# Altium schematic sheet dcdc300v.SchDoc(dcdc300v)
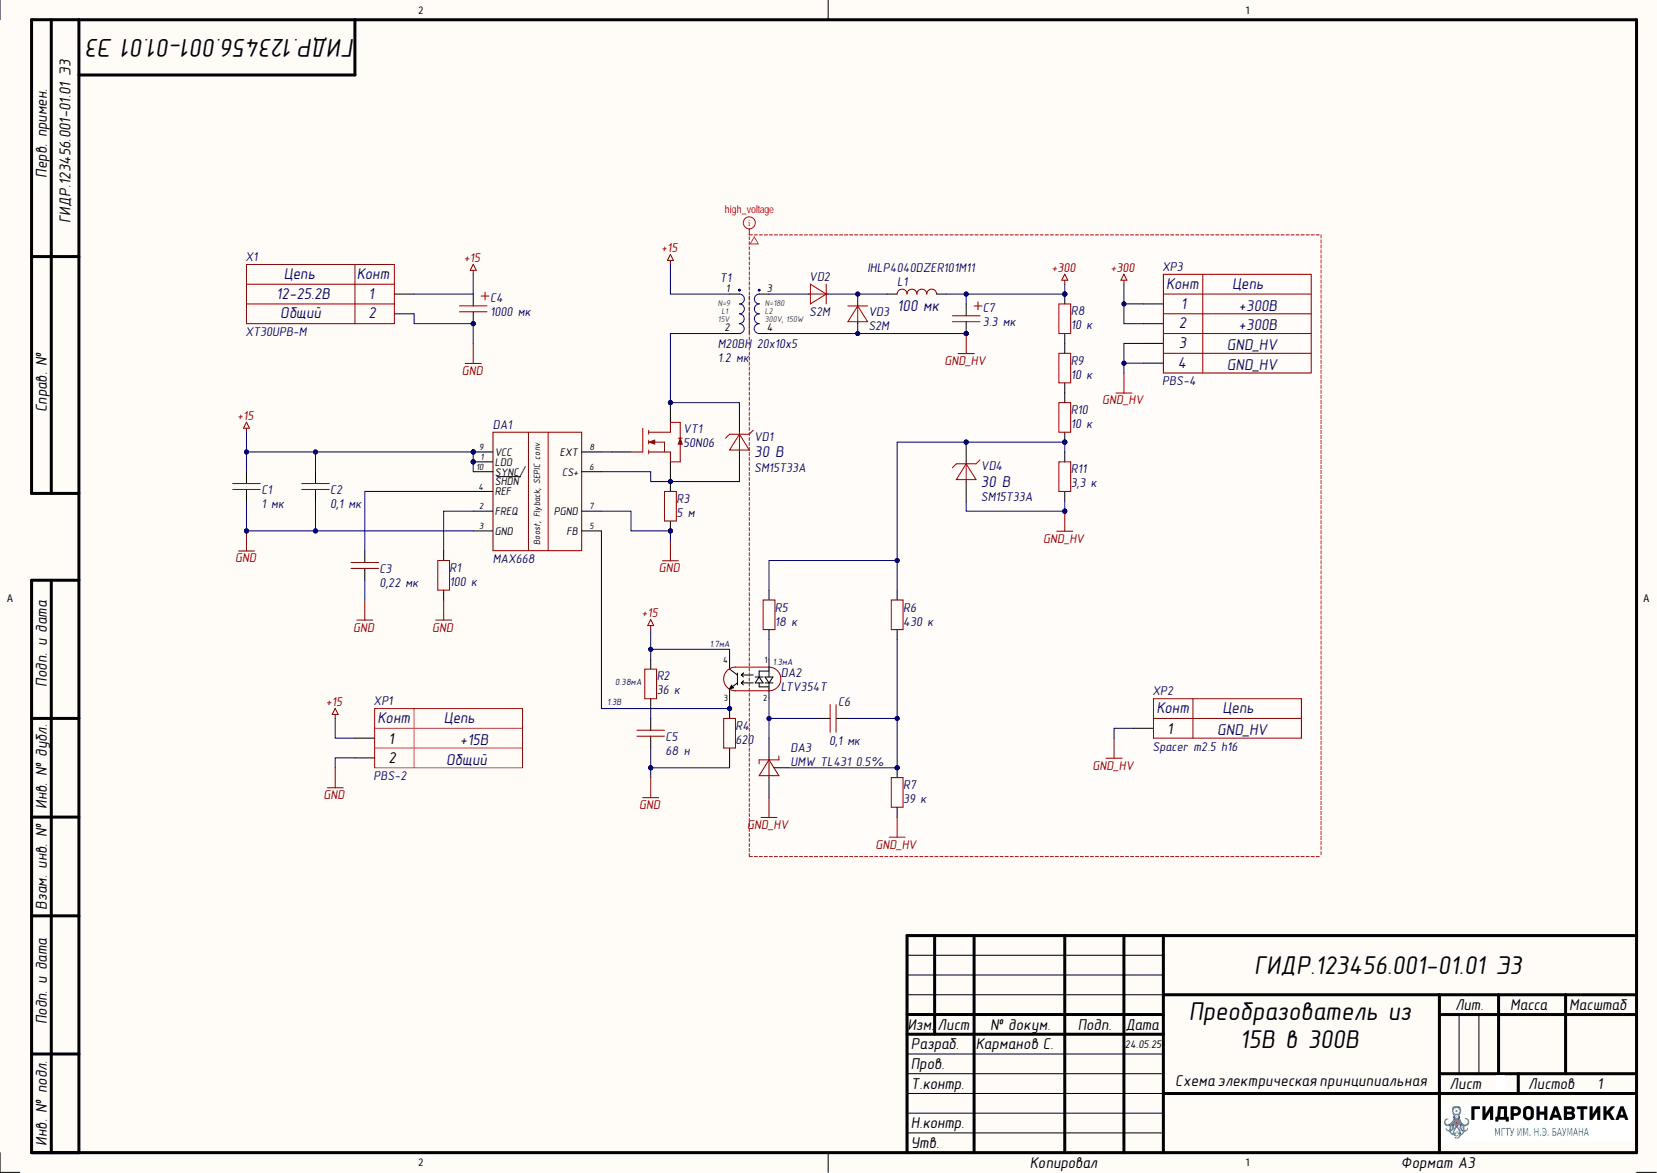
[diagram p0: dcdc300v.SchDoc]

## Parts
C1  value 1 мк  [0603C]  (hidden comment: Capacitor)
C2  value 0,1 мк  Tolerance: 0,1  [0603C]  (hidden comment: Capacitor)
C3  value 0,22 мк  [0603C]  (hidden comment: Capacitor)
C4  value 1000 мк  Capacity (uF): 1000  [CAP_EEVFK1V102M]  (hidden comment: Capacitor)
C5  value 68 н  [0603C]  (hidden comment: Capacitor)
C6  value 0,1 мк  Tolerance: 0,1  [0603C]  (hidden comment: Capacitor)
C7  value 3.3 мк  [8x12_radial]  (hidden comment: Capacitor)
DA1  MAX668  [uSOP10]
DA2  LTV354T  [SOP4-2_54]
DA3  UMW TL431 0.5%  [SOT23-3]
L1  IHLP4040DZER101M11  value 100 мк  [IHLP-4040]
R1  value 100 к  [0603R]  (hidden comment: Resistor)
R2  value 36 к  [0603R]  (hidden comment: Resistor)
R3  value 5 м  [2512R]  (hidden comment: Resistor)
R4  value 620  [0603R]  (hidden comment: Resistor)
R5  value 18 к  [0603R]  (hidden comment: Resistor)
R6  value 430 к  [0603R]  (hidden comment: Resistor)
R7  value 39 к  [0603R]  (hidden comment: Resistor)
R8  value 10 к  [1218R]  (hidden comment: Resistor)
R9  value 10 к  [1218R]  (hidden comment: Resistor)
R10  value 10 к  [1218R]  (hidden comment: Resistor)
R11  value 3,3 к  [1210R]  (hidden comment: Resistor)
T1  М20ВН 20х10х5  value 1.2 мк  [M20VN]
VD1  SM15T33A  value 30 В  [SMC]
VD2  S2M  [SMB]
VD3  S2M  [SMB]
VD4  SM15T33A  value 30 В  [SMC]
VT1  50N06  [DPAK]
X1  XT30UPB-M  Value1: 12-25.2В  Value2: Общий  [XT30UPB-M]
XP1  PBS-2  Value1: +15В  Value2: Общий  [PBS2_8.5]
XP2  Spacer m2.5 h16  Value1: GND_HV  [spacer_m2.5_h16]
XP3  PBS-4  Value1: +300В  Value3: GND_HV  [PBS4_8.5]

## Nets
+15: C1.2 C2.2 C4.1 DA1.1(LDO) DA1.9(VCC) DA1.10(SYNC/) DA2.4 R2.2 T1.1 X1.P XP1.1
+300: C7.1 L1.2 R8.2 XP3.1 XP3.2
GND: C1.1 C2.1 C3.1 C4.2 C5.1 DA1.3(GND) DA1.7(PGND) R1.1 R3.1 R4.1 X1.N XP1.2
GND_HV: C7.2 DA3.3 R7.1 R11.1 T1.4 VD3.1 VD4.1 XP2.1 XP3.3 XP3.4
NetC3_2: C3.2 DA1.4(REF)
NetC5_2: C5.2 DA1.5(FB) DA2.3 R2.1 R4.2
NetC6_1: C6.1 DA2.2 DA3.2
NetC6_2: C6.2 DA3.1 R6.2 R7.2
NetDA1_2: DA1.2(FREQ) R1.2
NetDA1_6: DA1.6(CS+) R3.2 VD1.1 VT1.3
NetDA1_8: DA1.8(EXT) VT1.1
NetDA2_1: DA2.1 R5.1
NetL1_1: L1.1 VD2.2 VD3.2
NetR5_2: R5.2 R6.1 R10.1 R11.2 VD4.2
NetR8_1: R8.1 R9.2
NetR9_1: R9.1 R10.2
NetT1_2: T1.2 VD1.2 VT1.2
NetT1_3: T1.3 VD2.1

## Library data of the parts
C1 = Capacitor_1u_10_50V_X7R_0603C: Конденсатор; Capacity (nF): 1000; Database Table Name: Capacitors_ceramic$; Dielectric: X7R; Library Path2: Schematic\Capacitor.SchLib; Tolerance: 0,1; Voltage (V): 50; Component Kind: Standard; Library Name: Hydrolib.DbLib; Part Number: Capacitor_1u_10_50V_X7R_0603C
C2 C6 = Capacitor_0,1u_10_50V_X7R_0603C: Конденсатор; Capacity (nF): 100; Database Table Name: Capacitors_ceramic$; Dielectric: X7R; Library Path2: Schematic\Capacitor.SchLib; Voltage (V): 50; Component Kind: Standard; Library Name: Hydrolib.DbLib; Part Number: Capacitor_0,1u_10_50V_X7R_0603C
C3 = Capacitor_0,22u_10_50V_X7R_0603C: Конденсатор; Capacity (nF): 220; Database Table Name: Capacitors_ceramic$; Dielectric: X7R; Library Path2: Schematic\Capacitor.SchLib; Tolerance: 0,1; Voltage (V): 50; Component Kind: Standard; Library Name: Hydrolib.DbLib; Part Number: Capacitor_0,22u_10_50V_X7R_0603C
C4 = EEVFK1V102M: Конденсатор алюминиевый электролитический 1000мкФ 25В 20% Low ESR 1100мА SMD12.5x13.5мм; Database Table Name: Capacitors_electrolytic$; Tolerance: 20; Type: SMD Aluminum; Voltage (V): 35; Component Kind: Standard; Library Name: Hydrolib.DbLib; Part Number: EEVFK1V102M
C5 = Capacitor_68n_10_50V_X7R_0603C: Конденсатор; Capacity (nF): 68; Database Table Name: Capacitors_ceramic$; Dielectric: X7R; Library Path2: Schematic\Capacitor.SchLib; Tolerance: 0,1; Voltage (V): 50; Component Kind: Standard; Library Name: Hydrolib.DbLib; Part Number: Capacitor_68n_10_50V_X7R_0603C
C7 = JRB2G3R3M03500800120000B: К50-35, 3.3мкФ, 400В, 105°C, 20%, 8x12мм P:3.5мм; Capacity (uF): 3,3; Database Table Name: Capacitors_electrolytic$; Tolerance: 20; Type: Aluminum; Voltage (V): 400; Component Kind: Standard; Library Name: Hydrolib.DbLib; Part Number: JRB2G3R3M03500800120000B
DA1 = MAX668: Boost, Flyback, SEPIC преобразователь. 10-uMAX/uSOP; Database Table Name: Chips$; MF: Maxim Integrated; MP: MAX668EUB+T; Availability: In Stock; Check_prices: https://www.snapeda.com/parts/MAX668EUB+T/Maxim+Integrated/view-part/?ref=eda; Component Kind: Standard; Library Name: Hydrolib.DbLib; Package: MICROMAX-10 Maxim; Part Number: MAX668; Price: None; Purchase-URL: https://pricing.snapeda.com/search/part/MAX668EUB+T/?ref=eda; SnapEDA_Link: https://www.snapeda.com/parts/MAX668EUB+T/Maxim+Integrated/view-part/?ref=snap
DA2 = LTV354T: Оптопара с транзисторным выходом, UMW; Database Table Name: Chips$; Check_prices: https://www.snapeda.com/parts/LTV816/Lite-On/view-part/?ref=eda; Component Kind: Standard; Library Name: Hydrolib.DbLib; Part Number: LTV354T; SnapEDA_Link: https://www.snapeda.com/parts/LTV816/Lite-On/view-part/?ref=snap
DA3 = UMW TL431 0.5%: Источник опорного напряжения , программируемый стабилитрон [SOT-23-3]; Database Table Name: Other$; Component Kind: Standard; Library Name: Hydrolib.DbLib; Part Number: UMW TL431 0.5%
L1 = IHLP4040DZER101M11: ЧИП-индуктивность экранированная 47мкГн ±20% 3,3A 145мОм 4040; Current (A): 3,3; Database Table Name: Inductance$; Inductance (μH): 100; Component Kind: Standard; Library Name: Hydrolib.DbLib; Part Number: IHLP4040DZER101M11
R1 = Resistor_100k_1_0603: Резистор номиналом 100 кОм и точностью 1%; Database Table Name: Resistors$; Component Kind: Standard; Library Name: Hydrolib.DbLib; Part Number: Resistor_100k_1_0603; Tolerance: 1%
R2 = Resistor_36k_1_0603: Резистор номиналом 36 кОм и точностью 1%; Database Table Name: Resistors$; Component Kind: Standard; Library Name: Hydrolib.DbLib; Part Number: Resistor_36k_1_0603; Tolerance: 1%
R3 = CRE2512-FZ-R005E-3: Резистор номиналом 5 мОм, точностью 1%, 3Вт; Database Table Name: Resistors$; Component Kind: Standard; Library Name: Hydrolib.DbLib; Part Number: CRE2512-FZ-R005E-3; Tolerance: 1%
R4 = Resistor_620_1_0603: Резистор номиналом 620 Ом и точностью 1%; Database Table Name: Resistors$; Component Kind: Standard; Library Name: Hydrolib.DbLib; Part Number: Resistor_620_1_0603; Tolerance: 1%
R5 = Resistor_18k_1_0603: Резистор номиналом 18 кОм и точностью 1%; Database Table Name: Resistors$; Component Kind: Standard; Library Name: Hydrolib.DbLib; Part Number: Resistor_18k_1_0603; Tolerance: 1%
R6 = Resistor_430k_1_0603: Резистор номиналом 430 кОм и точностью 1%; Database Table Name: Resistors$; Component Kind: Standard; Library Name: Hydrolib.DbLib; Part Number: Resistor_430k_1_0603; Tolerance: 1%
R7 = Resistor_39k_1_0603: Резистор номиналом 39 кОм и точностью 1%; Database Table Name: Resistors$; Component Kind: Standard; Library Name: Hydrolib.DbLib; Part Number: Resistor_39k_1_0603; Tolerance: 1%
R8-R10 = Resistor_10k_1_0603: Резистор номиналом 10 кОм и точностью 1%; Database Table Name: Resistors$; Component Kind: Standard; Library Name: Hydrolib.DbLib; Part Number: Resistor_10k_1_0603; Tolerance: 1%
R11 = Resistor_3.3k_1_0603: Резистор номиналом 3,3 кОм и точностью 1%; Database Table Name: Resistors$; Component Kind: Standard; Library Name: Hydrolib.DbLib; Part Number: Resistor_3.3k_1_0603; Tolerance: 1%
T1 = M20VN: Накопительная индуктивность для обратноходового преобразователя, L1=1.2uH, магнитопровод М20ВН, 20х10х5, первичная обмотка d1.3мм; Current (A): 15; Database Table Name: Inductance$; Inductance (μH): 1,2; Component Kind: Standard; Library Name: Hydrolib.DbLib; Part Number: M20VN
VD1 VD4 = SM15T33A: Защитный диод на 30 В, рассеиваемая мощность 1500 Вт; Database Table Name: TVS$; Power (W): 1500; Voltage nominal (V): 30; Component Kind: Standard; Library Name: Hydrolib.DbLib; Part Number: SM15T33A
VD2 VD3 = S2M: Выпрямительный диод на 1000 В, ном. ток 2 А; Current (A): 2; Database Table Name: Rectifier Diodes$; Repetitive peak reverse voltage (Vrrm): 1000; Component Kind: Standard; Library Name: Hydrolib.DbLib; Part Number: S2M
VT1 = 50N06: N-канальный MOSFET; Database Table Name: Transistors$; Напряжение С-И/К-Э: 60 В; Ток макс#: 50 А; Component Kind: Standard; Library Name: Hydrolib.DbLib; Part Number: 50N06
X1 = XT30UPB-M: 2-пиновый разъем с ключом; Database Table Name: Connectors$; System: Connector; Component Kind: Standard; Library Name: Hydrolib.DbLib; Part Number: XT30UPB-M
XP1 = PBS-2: Розетка 4 пина высота 8.5; Database Table Name: Connectors$; System: Connector; Component Kind: Standard; Datasheet: https://static.chipdip.ru/lib/986/DOC012986355.pdf; Library Name: Hydrolib.DbLib; Part Number: PBS-2
XP2 = spacer_m2.5_h16: Стойка М2.5, высота 16мм; Database Table Name: Other$; Component Kind: Standard; Library Name: Hydrolib.DbLib; Part Number: spacer_m2.5_h16
XP3 = PBS-4: Розетка 4 пина высота 8.5; Database Table Name: Connectors$; System: Connector; Component Kind: Standard; Datasheet: https://static.chipdip.ru/lib/986/DOC012986355.pdf; Library Name: Hydrolib.DbLib; Part Number: PBS-4; Value2: +300В; Value4: GND_HV
